# Altium schematic sheet DuckPi.SchDoc
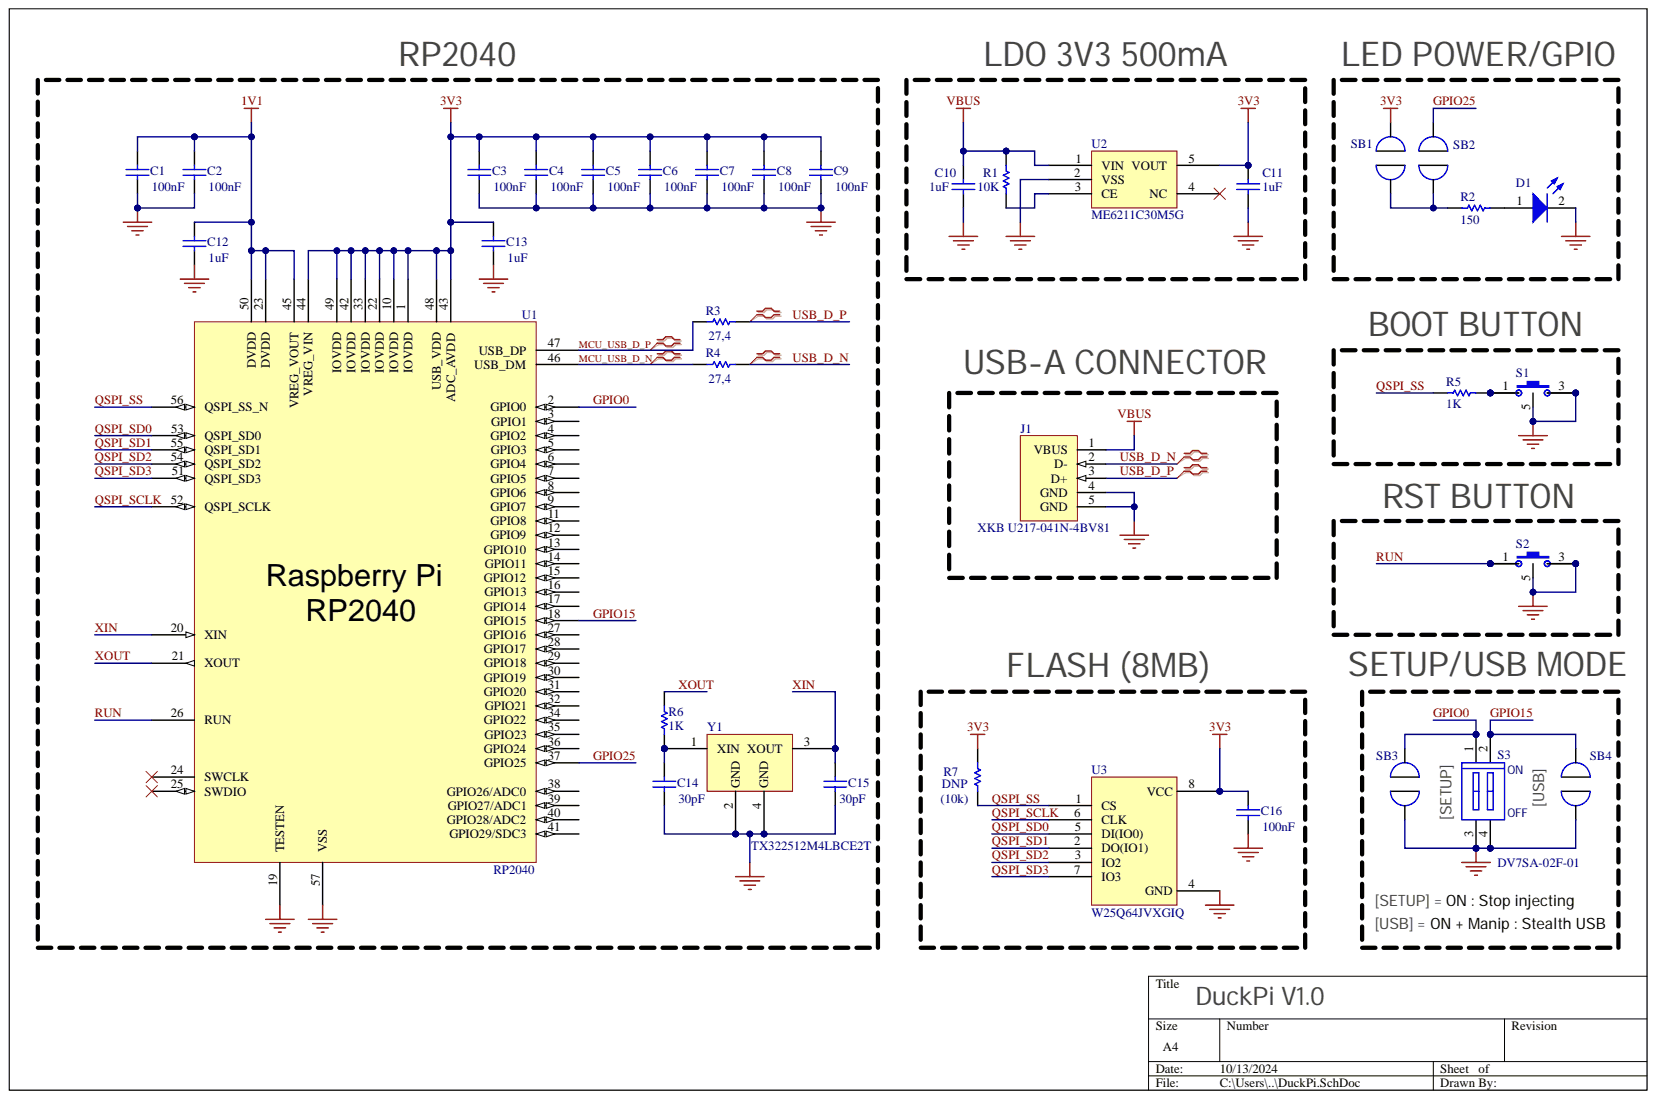
[diagram p0: DuckPi.SchDoc]

## Parts
C1  value 100nF  [CONDENSATEUR_CMS_0402-NoOverlay]  (hidden comment: CMS_0402)
C2  value 100nF  [CONDENSATEUR_CMS_0402-NoOverlay]  (hidden comment: CMS_0402)
C3  value 100nF  [CONDENSATEUR_CMS_0402-NoOverlay]  (hidden comment: CMS_0402)
C4  value 100nF  [CONDENSATEUR_CMS_0402-NoOverlay]  (hidden comment: CMS_0402)
C5  value 100nF  [CONDENSATEUR_CMS_0402-NoOverlay]  (hidden comment: CMS_0402)
C6  value 100nF  [CONDENSATEUR_CMS_0402-NoOverlay]  (hidden comment: CMS_0402)
C7  value 100nF  [CONDENSATEUR_CMS_0402-NoOverlay]  (hidden comment: CMS_0402)
C8  value 100nF  [CONDENSATEUR_CMS_0402-NoOverlay]  (hidden comment: CMS_0402)
C9  value 100nF  [CONDENSATEUR_CMS_0402-NoOverlay]  (hidden comment: CMS_0402)
C10  value 1uF  [CONDENSATEUR_CMS_0402-NoOverlay]  (hidden comment: CMS_0402)
C11  value 1uF  [CONDENSATEUR_CMS_0402-NoOverlay]  (hidden comment: CMS_0402)
C12  value 1uF  [CONDENSATEUR_CMS_0402-NoOverlay]  (hidden comment: CMS_0402)
C13  value 1uF  [CONDENSATEUR_CMS_0402-NoOverlay]  (hidden comment: CMS_0402)
C14  value 30pF  [CONDENSATEUR_CMS_0402-NoOverlay]  (hidden comment: CMS_0402)
C15  value 30pF  [CONDENSATEUR_CMS_0402-NoOverlay]  (hidden comment: CMS_0402)
C16  value 100nF  [CONDENSATEUR_CMS_0402-NoOverlay]  (hidden comment: CMS_0402)
D1  LED_CMS_0603  [LED_CMS_0603_NoOverlay]  (value not drawn)
J1  XKB U217-041N-4BV81  [U217-041N-4BV81-NOOVERLAY]
R1  value 10K  [CONDENSATEUR_CMS_0402-NoOverlay]  (hidden comment: CMS_0402)
R2  value 150  [CONDENSATEUR_CMS_0402-NoOverlay]  (hidden comment: CMS_0402)
R3  value 27,4  [CONDENSATEUR_CMS_0402-NoOverlay]  (hidden comment: CMS_0402)
R4  value 27,4  [CONDENSATEUR_CMS_0402-NoOverlay]  (hidden comment: CMS_0402)
R5  value 1K  [CONDENSATEUR_CMS_0402-NoOverlay]  (hidden comment: CMS_0402)
R6  value 1K  [CONDENSATEUR_CMS_0402-NoOverlay]  (hidden comment: CMS_0402)
R7  value DNP  [CONDENSATEUR_CMS_0402-NoOverlay]  (hidden comment: CMS_0402)
S1  TSA007A1518B03  [TSA007A1518B03]  (value not drawn)
S2  TSA007A1518B03  [TSA007A1518B03]  (value not drawn)
S3  DV7SA-02F-01  [DV7SA-02F-01]
SB1  SOLDER_BRIDGE  [SOLDER_BRIDGE]  (value not drawn)
SB2  SOLDER_BRIDGE  [SOLDER_BRIDGE]  (value not drawn)
SB3  SOLDER_BRIDGE  [SOLDER_BRIDGE]  (value not drawn)
SB4  SOLDER_BRIDGE  [SOLDER_BRIDGE]  (value not drawn)
U1  RP2040  [RP2040]
U2  ME6211C30M5G  [ME6211C30M5G]
U3  W25Q64JVXGIQ  [W25Q64JVXGIQ]
Y1  TX322512M4LBCE2T  [TX322512M4LBCE2T]

## Nets
1V1: C1.2 C2.2 C12.2 U1.23(DVDD) U1.45(VREG_VOUT) U1.50(DVDD)
3V3: C3.2 C4.2 C5.2 C6.2 C7.2 C8.2 C9.2 C11.2 C13.2 C16.2 R7.2 SB1.1 U1.1(IOVDD) U1.10(IOVDD) U1.22(IOVDD) U1.33(IOVDD) U1.42(IOVDD) U1.43(ADC_AVDD) U1.44(VREG_VIN) U1.48(USB_VDD) U1.49(IOVDD) U2.5(VOUT) U3.8(VCC)
GND: C1.1 C2.1 C3.1 C4.1 C5.1 C6.1 C7.1 C8.1 C9.1 C10.1 C11.1 C12.1 C13.1 C14.1 C15.1 C16.1 D1.2 J1.4(GND) J1.5(GND) S1.3 S1.4 S1.5 S2.3 S2.4 S2.5 S3.3 S3.4 SB3.2 SB4.2 U1.19(TESTEN) U1.57(VSS) U2.2(VSS) U3.4(GND) Y1.2(GND) Y1.4(GND)
VBUS: C10.2 J1.1(VBUS) R1.1 U2.1(VIN)
GPIO0: S3.1 SB3.1 U1.2(GPIO0)
GPIO15: S3.2 SB4.1 U1.18(GPIO15)
GPIO25: SB2.1 U1.37(GPIO25)
MCU_USB_D_N: R4.1 U1.46(USB_DM)
MCU_USB_D_P: R3.1 U1.47(USB_DP)
QSPI_SCLK: U1.52(QSPI_SCLK) U3.6(CLK)
QSPI_SD0: U1.53(QSPI_SD0) U3.5(DI(IO0))
QSPI_SD1: U1.55(QSPI_SD1) U3.2(DO(IO1))
QSPI_SD2: U1.54(QSPI_SD2) U3.3(IO2)
QSPI_SD3: U1.51(QSPI_SD3) U3.7(IO3)
QSPI_SS: R5.2 R7.1 U1.56(QSPI_SS_N) U3.1(CS)
RUN: S2.1 S2.2 U1.26(RUN)
USB_D_N: J1.2(D-) R4.2
USB_D_P: J1.3(D+) R3.2
XIN: C15.2 U1.20(XIN) Y1.3(XOUT)
XOUT: R6.2 U1.21(XOUT)
NetC14_2: C14.2 R6.1 Y1.1(XIN)
NetD1_1: D1.1 R2.2
NetR1_2: R1.2 U2.3(CE)
NetR2_1: R2.1 SB1.2 SB2.2
NetR5_1: R5.1 S1.1 S1.2

Not connected: U1 3(GPIO1) 4(GPIO2) 5(GPIO3) 6(GPIO4) 7(GPIO5) 8(GPIO6) 9(GPIO7) 11(GPIO8) 12(GPIO9) 13(GPIO10) 14(GPIO11) 15(GPIO12) 16(GPIO13) 17(GPIO14) 24(SWCLK) 25(SWDIO) 27(GPIO16) 28(GPIO17) 29(GPIO18) 30(GPIO19) 31(GPIO20) 32(GPIO21) 34(GPIO22) 35(GPIO23) 36(GPIO24) 38(GPIO26/ADC0) 39(GPIO27/ADC1) 40(GPIO28/ADC2) 41(GPIO29/SDC3); U2 4(NC)

## Library data of the parts
C1-C16 = CONDENSATEUR_CMS_CER_0402: CONDENSATEUR CMS CERAMIQUE 0402; Component Kind: Standard; Signal Integrity: Cap; Simulation: CAP; Type: CMS_0402; Library Name: composants passifs.SchLib
D1 = LED_CMS_0603: LED CMS 0603; Component Kind: Standard; Simulation: LED2; Type: CMS_0603; Library Name: affichage.SchLib
J1 = U217-041N-4BV81: 1.5A USB A 2.0 Male; Component Kind: Standard; Manufacturer Part Number: U217-041N-4BV81; Supplier 1: LCSC; Supplier Part Number 1: C319178; Library Name: MaryLab Projets.SchLib
R1-R7 = RESISTANCE_CMS_0402: RESISTANCE CMS 0402; Component Kind: Standard; Type: CMS_0402; Library Name: composants passifs.SchLib
S1 S2 = TSA007A1518B03: 3.35mm 1.5mm 50mA Round Tactile Switch; Component Kind: Standard; Library Name: MaryLab Projets.SchLib
S3 = DV7SA-02F-01: 2Bit SPST Black Slide SMD,3.67x5.7x2.1mm; Component Kind: Standard; Library Name: MaryLab Projets.SchLib
U1 = RP2040: 264KB 133MHz LQFN-56(7x7) MCU; Component Kind: Standard; Manufacturer Part Number: RP2040; Supplier 1: LCSC; Supplier Part Number 1: C2040; Library Name: MaryLab Projets.SchLib
U2 = ME6211C30M5G: LDO 3V3 500mA SOT-23-5; Component Kind: Standard; Library Name: MaryLab Projets.SchLib
U3 = W25Q64JVXGIQ: XSON-8-EP(4x4) NOR FLASH 3V 64M-BIT; Component Kind: Standard; Manufacturer Part Number: W25Q64JVXGIQ; Supplier: LCSC; Supplier Part Number: C2940195; Library Name: MaryLab Projets.SchLib
Y1 = TX322512M4LBCE2T: 12MHz 20pF ±10ppm ±30ppm SMD3225-4P Crystals; Component Kind: Standard; Manufacturer Part Number: TX322512M4LBCE2T; Supplier: LCSC; Supplier Part Number: C5182760; Library Name: MaryLab Projets.SchLib
SB1-SB4 = SOLDER_BRIDGE: Component Kind: Standard; Library Name: MOLKKY_2023_2024_Librairies.SchLib
